# Altium schematic sheet WIFI_XR829MQ&IR.SchDoc(WIFI_XR829MQ&IR)
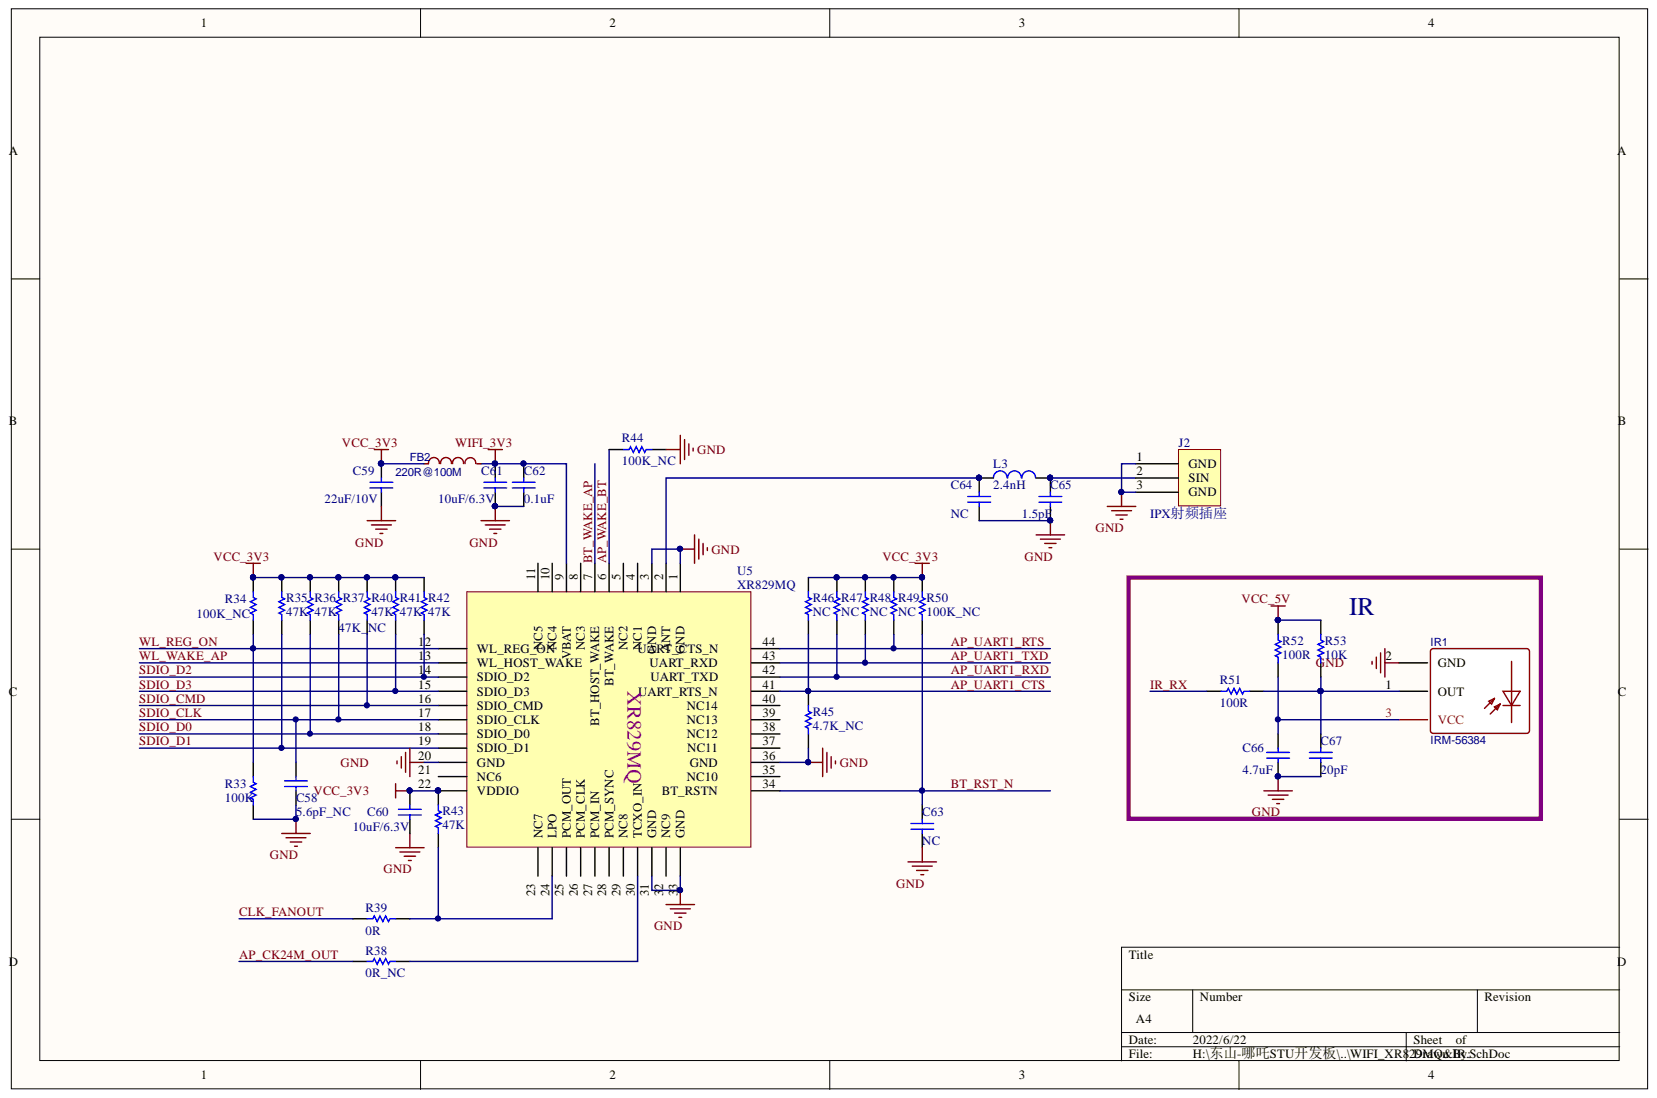
[diagram p0: WIFI_XR829MQ&IR.SchDoc]

## Parts
C58  5.6pF_NC  [0402]
C59  22uF/10V  [0603]
C60  10uF/6.3V  [0603]
C61  10uF/6.3V  [0603]
C62  0.1uF  [0402]
C63  NC  [0402]
C64  NC  [0402]
C65  1.5pF  [0402]
C66  4.7uF  [0402]
C67  20pF  [0402]
FB2  220R@100M  [L0603]
IR1  IRM-56384  [OPTO-TH_IRM-56384]
J2  U.FL-R-SMT-1(80)  [IPX_RECEPTACLE]  (value not drawn)
L3  2.4nH  [0402]
R33  100K  [0402]
R34  100K_NC  [0402]
R35  47K  [0402]
R36  47K  [0402]
R37  47K_NC  [0402]
R38  0R_NC  [0402]
R39  0R  [0402]
R40  47K  [0402]
R41  47K  [0402]
R42  47K  [0402]
R43  47K  [0402]
R44  100K_NC  [0402]
R45  4.7K_NC  [0402]
R46  NC  [0402]
R47  NC  [0402]
R48  NC  [0402]
R49  NC  [0402]
R50  100K_NC  [0402]
R51  100R  [0402]
R52  100R  [0402]
R53  10K  [0402]
U5  XR829MQ  [XR829MQ]

## Nets
GND: C58.1 C59.1 C60.1 C61.1 C62.1 C63.1 C64.1 C65.1 C66.2 C67.1 IR1.2 J2.1 J2.3 R33.1 R44.1 R45.1 U5.1 U5.3 U5.20(GND) U5.31(GND) U5.33(GND) U5.36
VCC_3V3: C59.2 C60.2 FB2.1 R34.2 R35.2 R36.2 R37.2 R40.2 R41.2 R42.2 R43.2 R46.2 R47.2 R48.2 R49.2 R50.2 U5.22(VDDIO)
VCC_5V: R52.1 R53.1
WIFI_3V3: C61.2 C62.2 FB2.2 U5.9
AP_CK24M_OUT: R38.2
AP_UART1_CTS: R45.2 R46.1 U5.41
AP_UART1_RTS: R49.1 U5.44
AP_UART1_RXD: R47.1 U5.42
AP_UART1_TXD: R48.1 U5.43
AP_WAKE_BT: R44.2 U5.6
BT_RST_N: C63.2 R50.1 U5.34
BT_WAKE_AP: U5.7
CLK_FANOUT: R39.2
IR_RX: R51.1
SDIO_CLK: C58.2 R37.1 U5.17(SDIO_CLK)
SDIO_CMD: R40.1 U5.16(SDIO_CMD)
SDIO_D0: R36.1 U5.18(SDIO_D0)
SDIO_D1: R35.1 U5.19(SDIO_D1)
SDIO_D2: R42.1 U5.14(SDIO_D2)
SDIO_D3: R41.1 U5.15(SDIO_D3)
WL_REG_ON: R33.2 R34.1 U5.12(WL_REG_ON)
WL_WAKE_AP: U5.13(WL_HOST_WAKE)
NetC64_2: C64.2 L3.1 U5.2
NetC65_2: C65.2 J2.2 L3.2
NetC66_1: C66.1 IR1.3 R52.2
NetC67_2: C67.2 IR1.1 R51.2 R53.2
NetR38_1: R38.1 U5.30(TCXO_IN)
NetR39_1: R39.1 R43.1 U5.24(LPO)

Not connected: U5 4 5 8 10 11 21(NC6) 23(NC7) 25(PCM_OUT) 26(PCM_CLK) 27(PCM_IN) 28(PCM_SYNC) 29(NC8) 32(NC9) 35 37 38 39 40

## Library data of the parts
C58-C67 = Cap: Capacitor; PartNumber: CAP100NF; Component Kind: Standard; LatestRevisionDate: 17-Jul-2002; LatestRevisionNote: Re-released for DXP Platform.; Library Name: Miscellaneous Devices.IntLib; PackageReference: 0402; Published: 8-Jun-2000; Signal Integrity: Cap; Simulation: CAP; Publisher: Altium Limited
  C58: Value: 5.6pF_NC
  C59: Value: 22uF/10V
  C60 C61: Value: 10uF/6.3V
  C62: Value: 0.1uF
  C63 C64: Value: NC
  C65: Value: 1.5pF
  C66: Value: 4.7uF
  C67: Value: 20pF
FB2 = (no symbol name): BOM_Manufacturer: MuRata; BOM_Manufacturer Part: BLM18KG221SN1D; nameAlias: Value; BOM_JLCPCB Part Class: Extended Part; BOM_Supplier: LCSC; BOM_Supplier Part: C88980; Component Kind: Standard; spicePre: L; spiceSymbolName: BLM18KG221SN1D
IR1 = IRM-56384_1: BOM_Manufacturer: EVERLIGHT; BOM_JLCPCB Part Class: Extended Part; BOM_Manufacturer Part: IRM-56384; BOM_Supplier: LCSC; BOM_Supplier Part: C72048; Component Kind: Standard; Library Name: HM2.SchLib; spicePre: I; spiceSymbolName: IRM-56384
J2 = IPX_RECEPTACLE: VALUE: IPX射频插座; Component Kind: Standard; JLC NO: C88374; Library Name: HM2.SchLib
L3 = Inductor: Component Kind: Standard; Library Name: MyDevice.SchLib; Value: 2.4nH
R33-R53 = Res1: Resistor; PartNumber: RES2.2K; Component Kind: Standard; LatestRevisionDate: 17-Jul-2002; LatestRevisionNote: Re-released for DXP Platform.; Library Name: Miscellaneous Devices.IntLib; PackageReference: 0402; Published: 8-Jun-2000; Signal Integrity: Res; Simulation: RESISTOR; Publisher: Altium Limited
  R33: Value: 100K
  R34 R44 R50: Value: 100K_NC
  R35 R36 R40-R43: Value: 47K
  R37: Value: 47K_NC
  R38: Value: 0R_NC
  R39: Value: 0R
  R45: Value: 4.7K_NC
  R46-R49: Value: NC
  R51 R52: Value: 100R
  R53: Value: 10K
U5 = XR829MQ: Component Kind: Standard; Library Name: HM2.SchLib
